# Altium schematic sheet mcu.SchDoc(mcu)
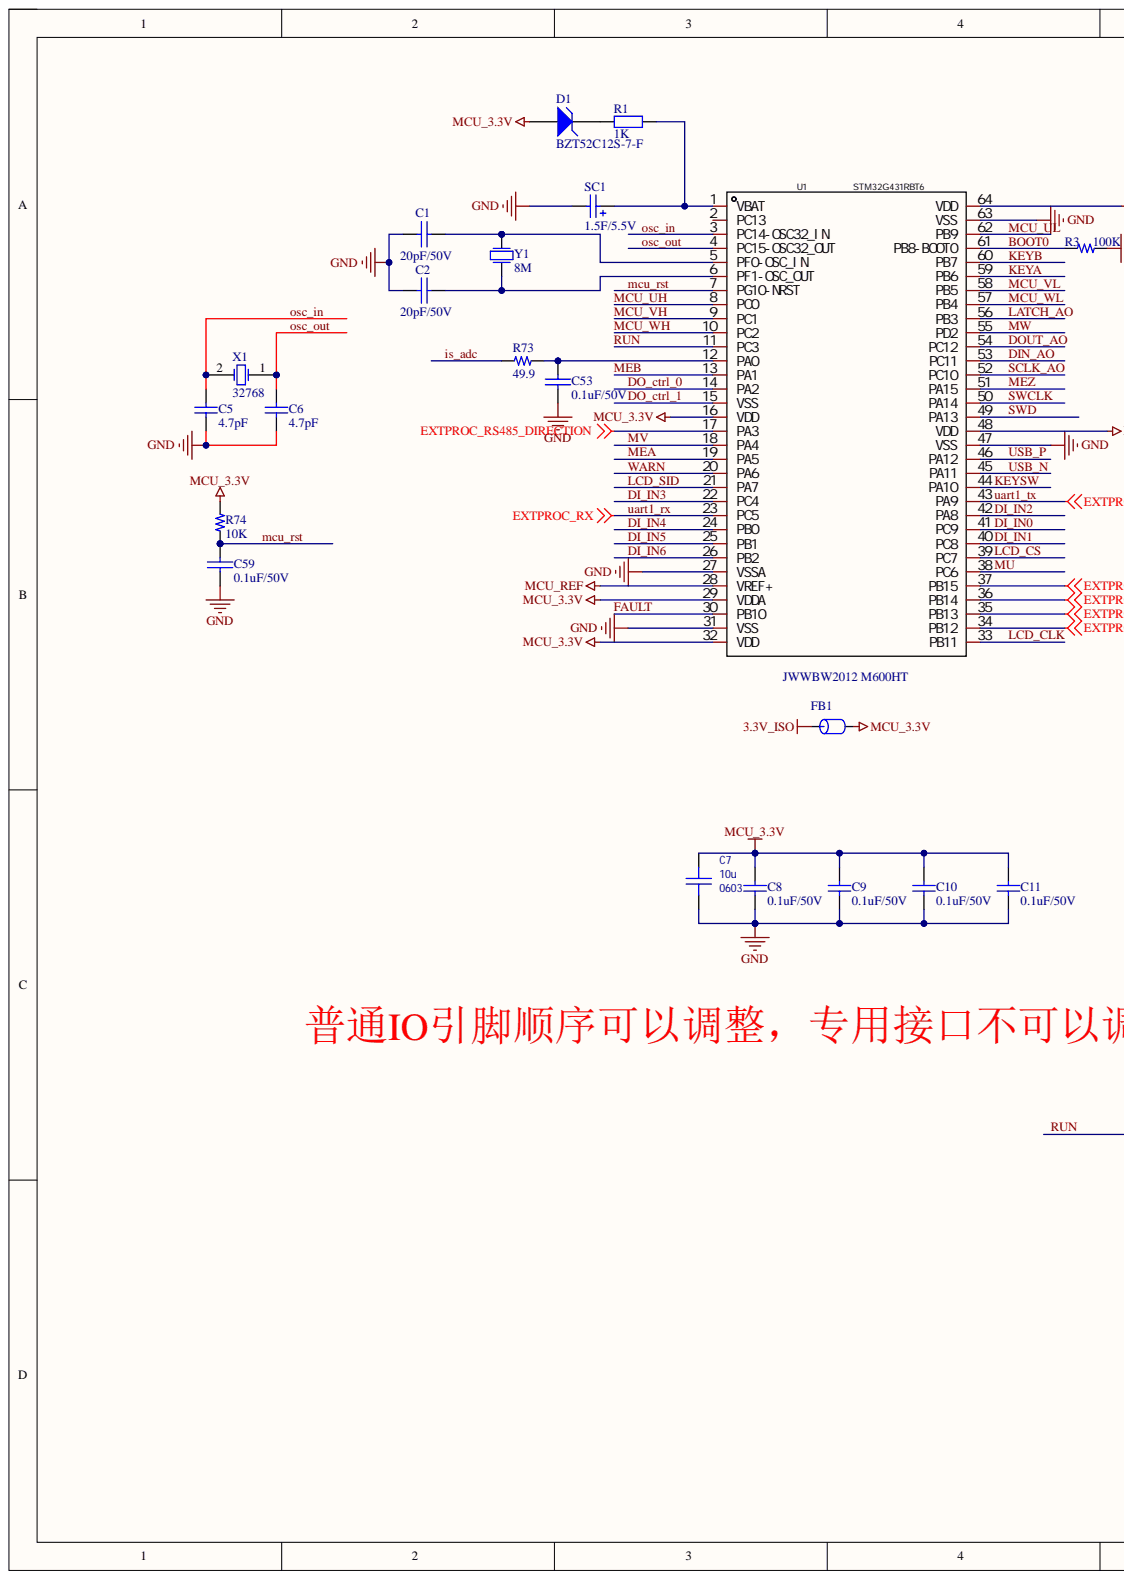
[diagram p0: mcu.SchDoc, left]
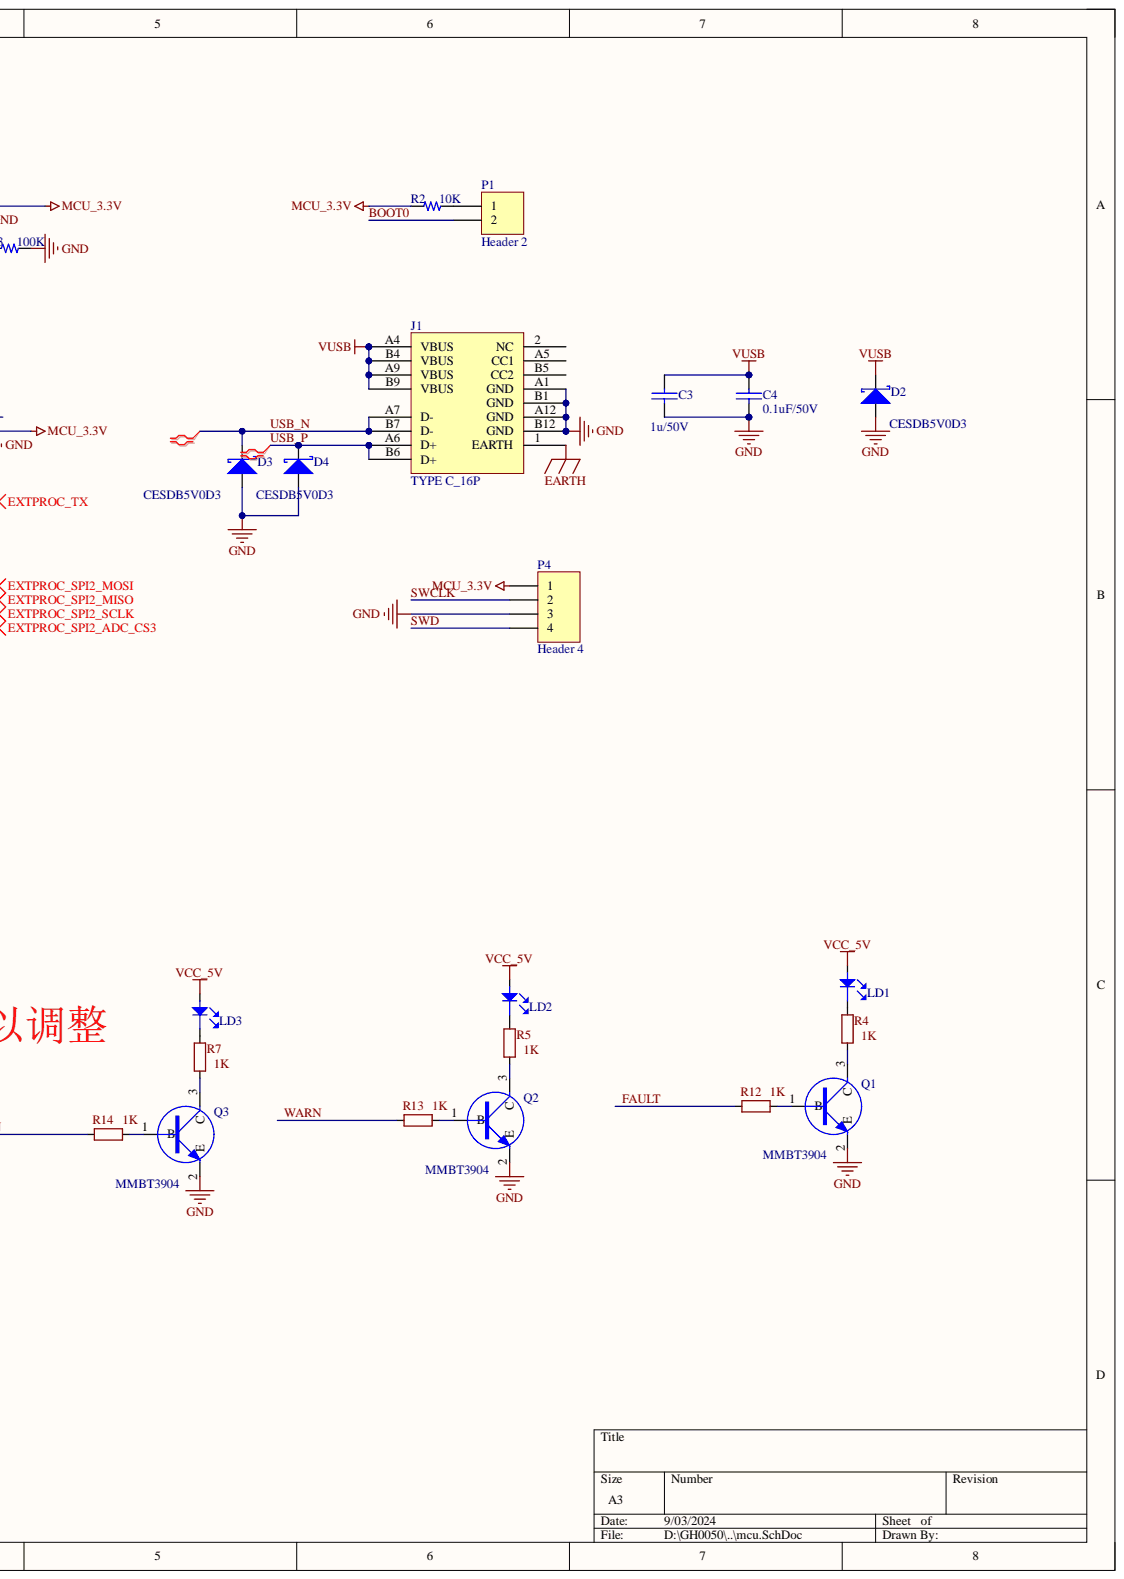
[diagram p1: mcu.SchDoc, right]

## Parts
C1  value 20pF/50V  [0603C]  (hidden comment: 20pF/25V)
C2  value 20pF/50V  [0603C]  (hidden comment: 20pF/25V)
C3  value 1u/50V  [0603C]  (hidden comment: 1uF/25V)
C4  0.1uF/50V  [0603C]
C5  4.7pF  [0603C]
C6  4.7pF  [0603C]
C7  value 10u  [CAPC1608X092N_0603]  (hidden comment: Capacitor_0603)
C8  0.1uF/50V  [0603C]
C9  0.1uF/50V  [0603C]
C10  0.1uF/50V  [0603C]
C11  0.1uF/50V  [0603C]
C53  0.1uF/50V  [0603C]
C59  0.1uF/50V  [0603C]
D1  BZT52C12S-7-F  [DIOD-SOD323-2_V]
D2  CESDB5V0D3  [SOD323]
D3  CESDB5V0D3  [SOD323]
D4  CESDB5V0D3  [SOD323]
FB1  JWWBW2012 M600HT  [0805R]
J1  TYPE C_16P  [TYPEC_16P]
LD1  LED_Green_19-217  [0603led]  (value not drawn)
LD2  LED_Green_19-217  [0603led]  (value not drawn)
LD3  LED_Green_19-217  [0603led]  (value not drawn)
P1  Header 2  [HDR1X2]
P4  Header 4  [HDR1X4]
Q1  MMBT3904  [SOT23-3]
Q2  MMBT3904  [SOT23-3]
Q3  MMBT3904  [SOT23-3]
R1  1K  [0603R]  (no BOM)
R2  10K  [0603R]
R3  100K  [0603R]
R4  1K  [0603r]
R5  1K  [0603r]
R7  1K  [0603r]
R12  1K  [0603r]
R13  1K  [0603r]
R14  1K  [0603r]
R73  49.9  [0603R]
R74  10K  [0603R]
SC1  1.5F/5.5V  [CAP-TH_DMS3R3224]
U1  STM32G431RBT6  [LQFP-64]
X1  32768  [A]
Y1  8M  [CRAY_5032]

## Nets
3.3V_ISO: FB1.1
EARTH: J1.1(EARTH)
EXTPROC_RS485_DIRECTION: U1.17(PA3)
EXTPROC_SPI2_ADC_CS3: U1.34(PB12)
EXTPROC_SPI2_MISO: U1.36(PB14)
EXTPROC_SPI2_MOSI: U1.37(PB15)
EXTPROC_SPI2_SCLK: U1.35(PB13)
GND: C1.2 C2.2 C3.1 C4.1 C5.2 C6.2 C7.2 C8.1 C9.1 C10.1 C11.1 C53.1 C59.1 D2.1 D3.1 D4.1 J1.A1(GND) J1.A12(GND) J1.B1(GND) J1.B12(GND) P4.3 Q1.2 Q2.2 Q3.2 R3.2 SC1.2 U1.27(VSSA) U1.31(VSS) U1.47(VSS) U1.63(VSS)
MCU_3.3V: C7.1 C8.2 C9.2 C10.2 C11.2 D1.1 FB1.2 P4.1 R2.1 R74.1 U1.16(VDD) U1.29(VDDA) U1.32(VDD) U1.48(VDD) U1.64(VDD)
MCU_REF: U1.28(VREF+)
VCC_5V: LD1.1 LD2.1 LD3.1
VUSB: C3.2 C4.2 D2.2 J1.A4(VBUS) J1.A9(VBUS) J1.B4(VBUS) J1.B9(VBUS)
BOOT0: P1.2 R3.1 U1.61(PB8-BOOT0)
DI_IN0: U1.41(PC9)
DI_IN1: U1.40(PC8)
DI_IN2: U1.42(PA8)
DI_IN3: U1.22(PC4)
DI_IN4: U1.24(PB0)
DI_IN5: U1.25(PB1)
DI_IN6: U1.26(PB2)
DIN_AO: U1.53(PC11)
DO_ctrl_0: U1.14(PA2)
DO_ctrl_1: U1.15(VSS)
DOUT_AO: U1.54(PC12)
FAULT: R12.1 U1.30(PB10)
is_adc: R73.1
KEYA: U1.59(PB6)
KEYB: U1.60(PB7)
KEYSW: U1.44(PA10)
LATCH_AO: U1.56(PB3)
LCD_CLK: U1.33(PB11)
LCD_CS: U1.39(PC7)
LCD_SID: U1.21(PA7)
mcu_rst: C59.2 R74.2 U1.7(PG10-NRST)
MCU_UH: U1.8(PC0)
MCU_UL: U1.62(PB9)
MCU_VH: U1.9(PC1)
MCU_VL: U1.58(PB5)
MCU_WH: U1.10(PC2)
MCU_WL: U1.57(PB4)
MEA: U1.19(PA5)
MEB: U1.13(PA1)
MEZ: U1.51(PA15)
MU: U1.38(PC6)
MV: U1.18(PA4)
MW: U1.55(PD2)
osc_in: C5.1 U1.3(PC14-OSC32_IN) X1.2
osc_out: C6.1 U1.4(PC15-OSC32_OUT) X1.1
RUN: R14.1 U1.11(PC3)
SCLK_AO: U1.52(PC10)
SWCLK: P4.2 U1.50(PA14)
SWD: P4.4 U1.49(PA13)
uart1_rx: U1.23(PC5)
uart1_tx: U1.43(PA9)
USB_N: D3.2 J1.A7(D-) J1.B7(D-) U1.45(PA11)
USB_P: D4.2 J1.A6(D+) J1.B6(D+) U1.46(PA12)
WARN: R13.1 U1.20(PA6)
NetC1_1: C1.1 U1.5(PF0-OSC_IN) Y1.1
NetC2_1: C2.1 U1.6(PF1-OSC_OUT) Y1.2
NetC53_2: C53.2 R73.2 U1.12(PA0)
NetD1_2: D1.2 R1.1
NetLD1_2: LD1.2 R4.1
NetLD2_2: LD2.2 R5.1
NetLD3_2: LD3.2 R7.1
NetP1_1: P1.1 R2.2
NetQ1_1: Q1.1 R12.2
NetQ1_3: Q1.3 R4.2
NetQ2_1: Q2.1 R13.2
NetQ2_3: Q2.3 R5.2
NetQ3_1: Q3.1 R14.2
NetQ3_3: Q3.3 R7.2
NetR1_2: R1.2 SC1.1 U1.1(VBAT)

Not connected: J1 2(NC) A5(CC1) B5(CC2); U1 2(PC13)

## Library data of the parts
C1-C4 C53 C59 = CAP: Component Kind: Standard; Library Name: SM.SCHLIB
  C4 C53 C59: Value: 0.1uF/50V
C5 = Cap: Capacitor; Value: 6.8pF; Component Kind: Standard; LatestRevisionDate: 17-Jul-2002; LatestRevisionNote: Re-released for DXP Platform.; Library Name: Miscellaneous Devices.IntLib; PackageDescription: Radial Cap, Thru-Hole; 2 Leads; 0.3 in Pin Spacing; PackageReference: RAD-0.3; Published: 8-Jun-2000; Signal Integrity: Cap; Simulation: CAP; Publisher: Altium Limited
C6 = Cap: Capacitor; Component Kind: Standard; LatestRevisionDate: 17-Jul-2002; LatestRevisionNote: Re-released for DXP Platform.; Library Name: Miscellaneous Devices.IntLib; PackageDescription: Radial Cap, Thru-Hole; 2 Leads; 0.3 in Pin Spacing; PackageReference: RAD-0.3; Published: 8-Jun-2000; Signal Integrity: Cap; Simulation: CAP; Publisher: Altium Limited
C7 = Capacitor_0603: Capacitor, MLCC; Manufacturer: Murata Electronics; PartNumber: GRM188R61A106KE69D; TempCo: [tempco]; Tolerance: [tol]; Voltage Rating: [voltage]; Component Kind: Standard; ComponentLink1Description: Datasheet; Package: 0603; SupplierDescription: CAP CER 10UF 10V X5R 0603; Library Name: Karl.SchLib
C8-C11 = Cap: Capacitor; Value: 22nF; Component Kind: Standard; LatestRevisionDate: 17-Jul-2002; LatestRevisionNote: Re-released for DXP Platform.; Library Name: Miscellaneous Devices.IntLib; PackageDescription: Radial Cap, Thru-Hole; 2 Leads; 0.3 in Pin Spacing; PackageReference: RAD-0.3; Published: 8-Jun-2000; Signal Integrity: Cap; Simulation: CAP; Publisher: Altium Limited
D1 = BZT52C12S-7-F: Pd - Power Dissipation: 200mW; Unit Weight: 0.000173oz; Voltage Temperature Coefficient: 0.25mV/C; Voltage Tolerance: 5%; Vz - Zener Voltage: 12V; Zener Current: 5mA; Zz - Zener Impedance: 40Ohms; Component Kind: Standard; Minimum Operating Temperature: -65C; Mounting Style: SMD/SMT; Mounting Technology: SurfaceMount; Package / Case: SOD-323; Package Description: 2-Pin Surface Mount Device, Body 1.7 x 1.3 mm; Package Reference: SOD323-2; Package URL: http://www.vishay.com/docs/71192/71192.pdf; Packaging: Reel; Product Category: ZenerDiodes; RoHS: True; Series: BZT52C12; Termination Style: SMD/SMT; Value: BZT52C12S-7-F; Width: 1.4mm; Library Name: Bogen.SchLib
D2 D3 = DIODE S: Component Kind: Standard
D4 = DIODE S: Value: 0.1uF/50V; Component Kind: Standard
FB1 = FB: Part Field 1: 磁珠/0603/1K ohm/100MHz; FB: BLM18BD102SN1(muRata); Component Kind: Standard; Library Name: Schlib_20110825.schlib; Text Field1: FB
LD1-LD3 = LED_Green_19-217: ID: 6; Value: 19-217/G7C-AN1P2/3T; 制造商: Everlight; 器件等级: ——; 工作温度: –40°C to 85°C; 序号: 33; 物料主编码(PN): 100000032; 物料名称: 绿色LED; 物料描述: Other,LED,绿色,0603,1.6X0.8X0.4mm,19-217/G7C-AN1P2/3T; 物料类型: 特殊二极管; Component Kind: Standard; Library Name: RC_M_D_00.IntLib; 规格型号(完整型号): 19-217/G7C-AN1P2/3T
Q1-Q3 = NPN_MMBT3904: Component Kind: Standard; Library Name: CenLib.SCHLIB
R1 = Res0805M: Library Name: MQlibrary.IntLib
R2 R3 R73 R74 = R: Value: 10k/1%; Component Kind: Standard; Library Name: JRJ_1.SCHLIB
R4 R5 R7 R12-R14 = RES0603: ID: 233; 制造商: 三星/YAGEO; 参数值: 1.00E+04; 器件等级: ——; 工作温度: –55°C to 155°C; 序号: 109; 物料主编码(PN): 150000040; 物料名称: 贴片电阻; 物料描述: RES,贴片电阻,0603,10k,±1%,1/10W,1.6X0.8X0.45mm,RC1608F103CS/RC0603FR-0710KL; 物料类型: 表贴电阻; 精度: ±1%; 规格型号(完整型号): RC1608F103CS/RC0603FR-0710KL; Component Kind: Standard; Library Name: RC_M_D_00.IntLib; Value: 1K
SC1 = Cap Pol2: Polarized Capacitor (Axial); Value: 100pF; Component Kind: Standard; LatestRevisionDate: 17-Jul-2002; LatestRevisionNote: Re-released for DXP Platform.; Library Name: Miscellaneous Devices.IntLib; PackageReference: POLAR0.8; Published: 8-Jun-2000; Publisher: Altium Limited
U1 = STM32G431RBT6: Manufacturer: ST(意法半导体); ADC (Bit): 12bit; Add into BOM: yes; CPU Maximum Speed: 170MHz; Direct Memory Access: 有; GPIO Ports Number: 52; Operating Voltage Range: 1.71V~3.6V; Program Storage Size: 128KB; Component Kind: Standard; Convert to PCB: yes; CPU Core: -; DAC (Bit): 12bit; Datasheet: https://atta.szlcsc.com/upload/public/pdf/source/20190925/C431633_8068E60CC825A086DCCD86A4BDED9458.pdf; Device: STM32G431RBT6; Infrared Data Association: 有; Internal Oscillator: 有; JLCPCB Part Class: Extended Part; LCSC Part Name: 带DSP和FPU的170MHz主流ARM Cortex-M4 MCU，具有128 KB Flash存储器、数学加速器和中等模拟电平集成; Low-Voltage Detect: 有; Manufacturer Part: STM32G431RBT6; Operating Temperature Range: -40℃~+85℃; PCB3D: LQFP-64_L10.0-W10.0-H1.4-LS12.0-P0.50; Supplier: LCSC; Supplier Footprint: LQFP-64(10x10); Supplier Part: C431633; Symbol: STM32G431RBT6; Watchdog: 有
X1 = XTAL: Crystal Oscillator; Component Kind: Standard; LatestRevisionDate: 17-Jul-2002; LatestRevisionNote: Re-released for DXP Platform.; Library Name: Miscellaneous Devices.IntLib; PackageReference: R38; Published: 8-Jun-2000; Simulation: XTAL; Publisher: Altium Limited
Y1 = XTAL: Component Kind: Standard; Library Name: Miscellaneous Devices.IntLib; Value: 8M
J1 = TYPE C_16P: Component Kind: Standard; Library Name: Schlib_20110825.schlib
P1 = Header 2: Component Kind: Standard; Library Name: Miscellaneous Connectors.IntLib; Signal Integrity: Connector; Publisher: Altium Limited
P4 = Header 4: Component Kind: Standard; Library Name: Miscellaneous Connectors.IntLib; Signal Integrity: Connector; Publisher: Altium Limited
